# Altium schematic sheet Wacky_Racers_87557913.SchDoc(Wacky_Racers_87557913)
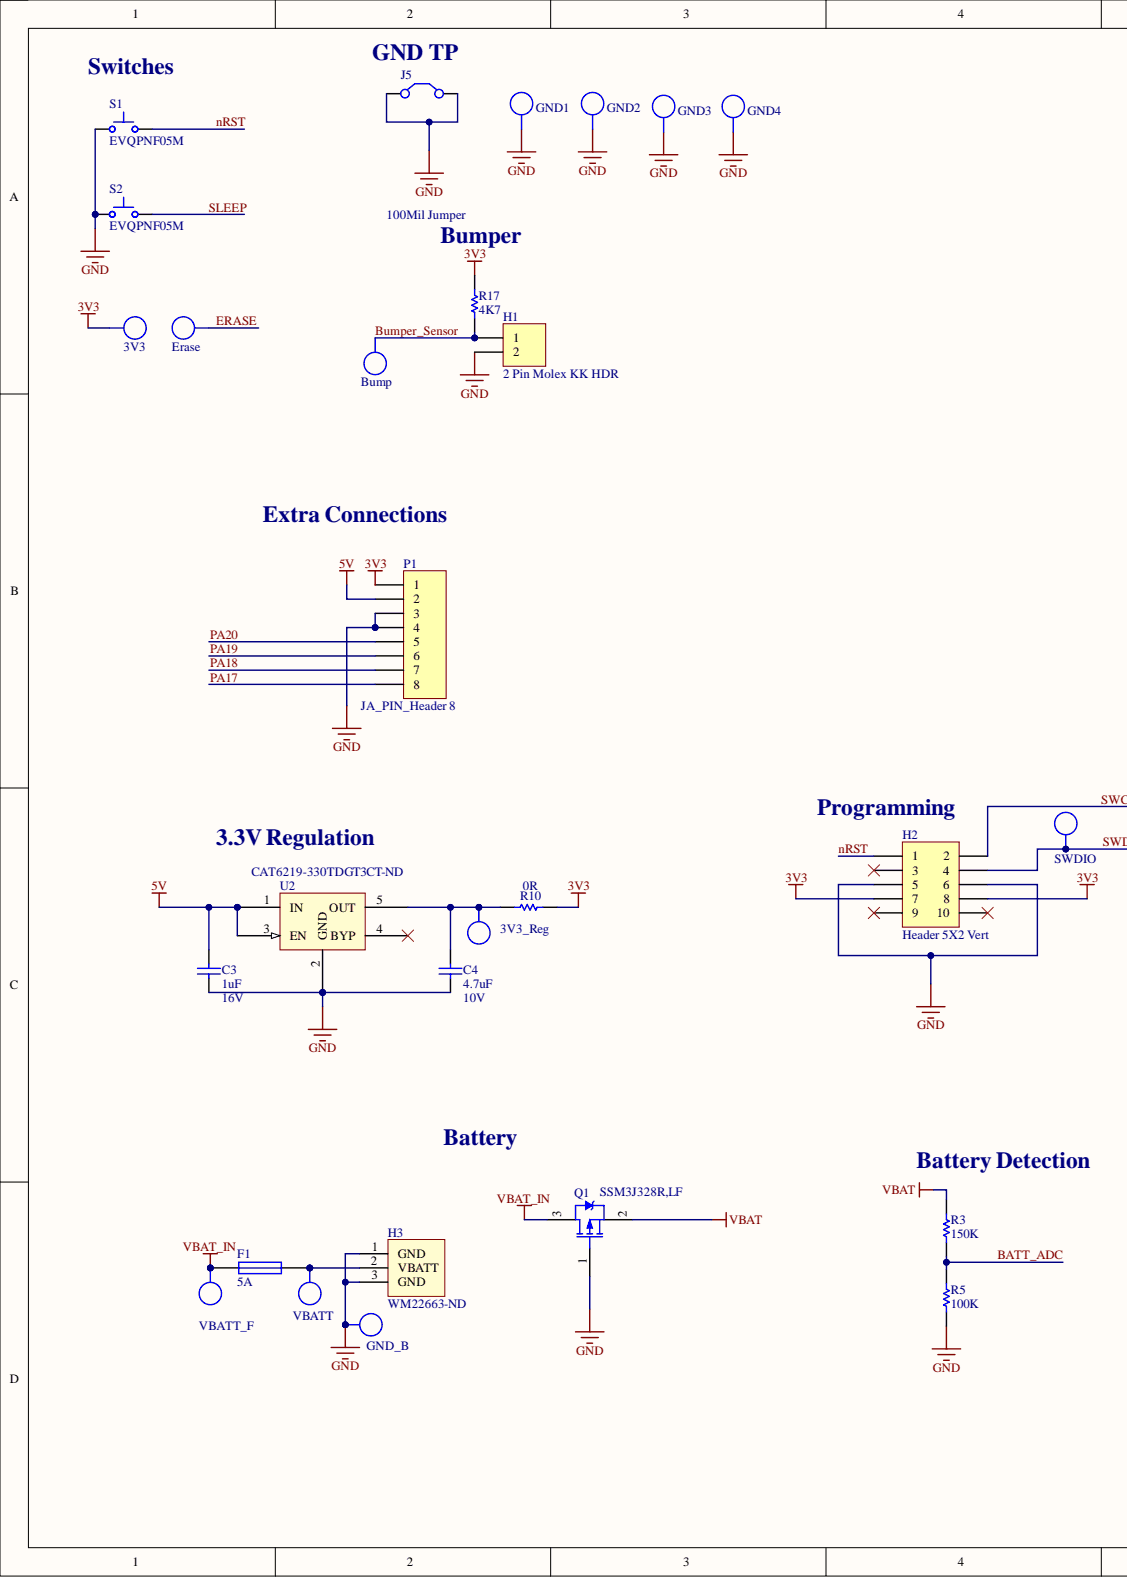
[diagram p0: Wacky_Racers_87557913.SchDoc, left]
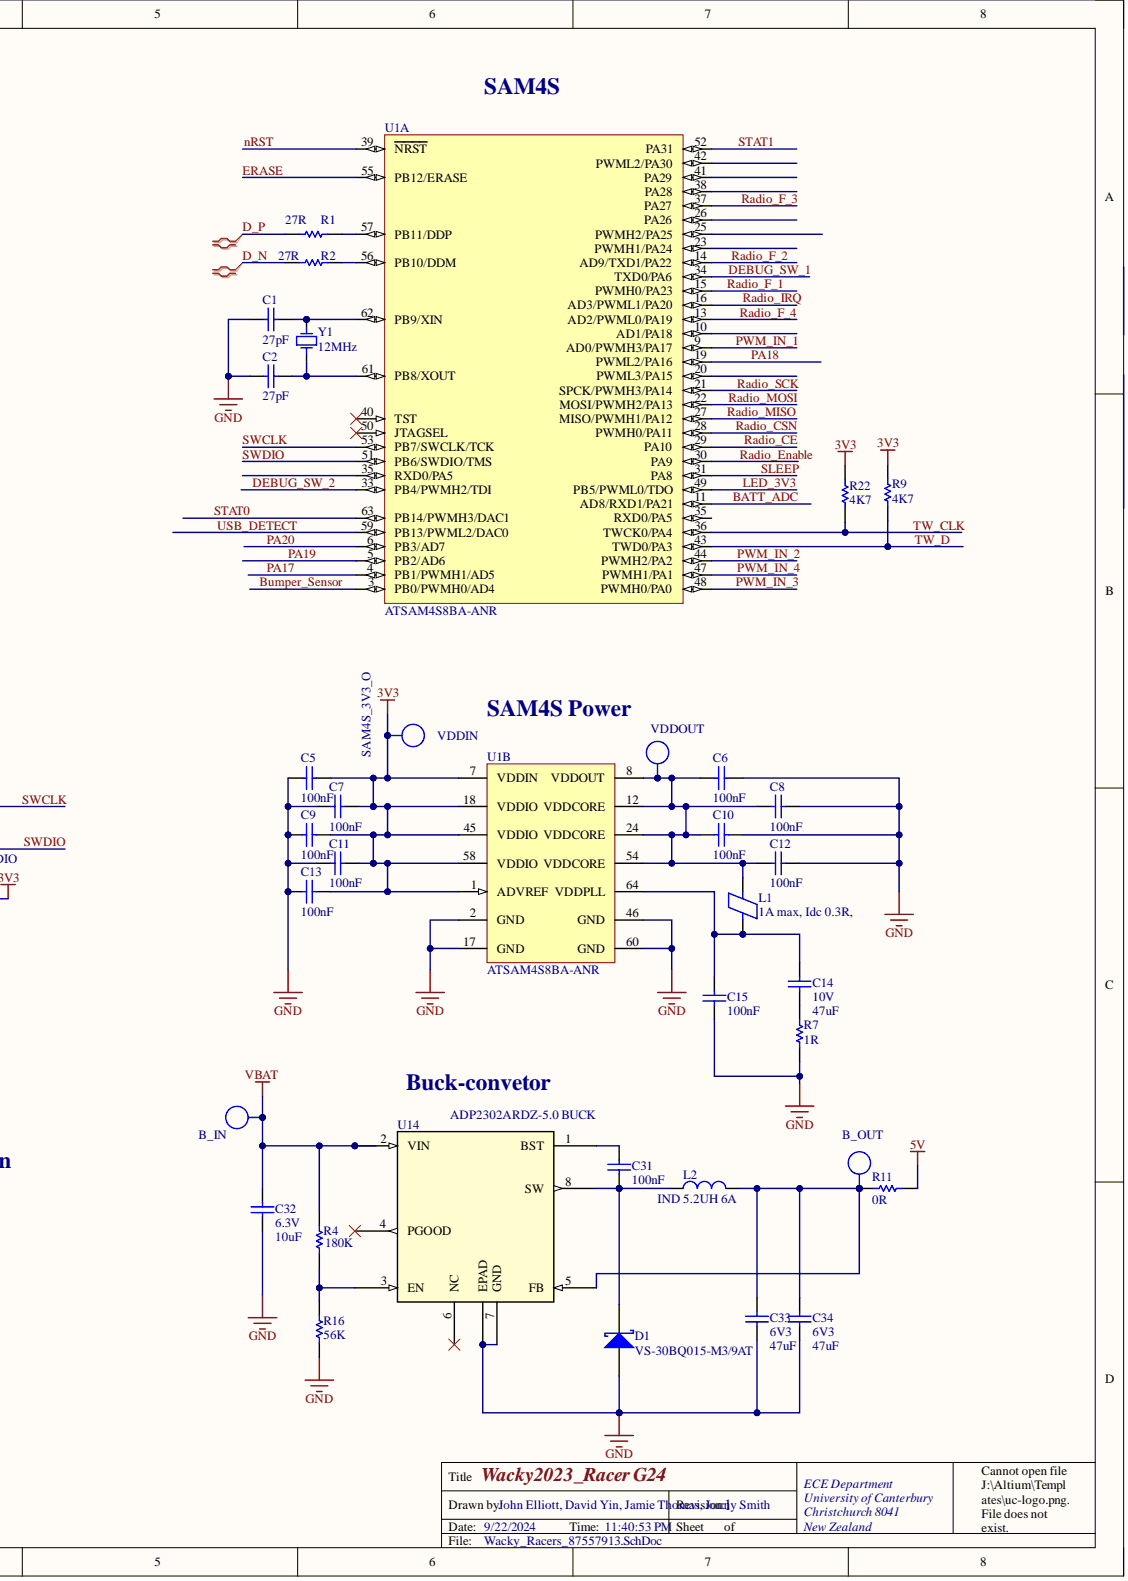
[diagram p1: Wacky_Racers_87557913.SchDoc, right]

## Parts
3V3  TP  (value not drawn)  (no BOM)
3V3_Reg  TP  (value not drawn)  (no BOM)
B_IN  TP  (value not drawn)  (no BOM)
B_OUT  TP  (value not drawn)  (no BOM)
Bump  TP  (value not drawn)  (no BOM)
C1  27pF
C2  27pF
C3  1uF  Max Voltage: 16V
C4  4.7uF  Max Voltage: 10V
C5  100nF
C6  100nF
C7  100nF
C8  100nF
C9  100nF
C10  100nF
C11  100nF
C12  100nF
C13  100nF
C14  47uF  Max Voltage: 10V  [1210 (3225 metric)]
C15  100nF
C31  100nF
C32  10uF  Voltage - Rated: 6.3V
C33  Capacitance: 47uF  Voltage Rating: 6V3  (hidden comment: CC_47uF_0805_6V3)
C34  Capacitance: 47uF  Voltage Rating: 6V3  (hidden comment: CC_47uF_0805_6V3)
D1  VS-30BQ015-M3/9AT
Erase  TP  (value not drawn)  (no BOM)
F1  5A
GND1  TP  (value not drawn)  (no BOM)
GND2  TP  (value not drawn)  (no BOM)
GND3  TP  (value not drawn)  (no BOM)
GND4  TP  (value not drawn)  (no BOM)
GND_B  TP  (value not drawn)  (no BOM)
H1  2 Pin Molex KK HDR
H2  Header 5X2 Vert
H3  Supplier Part Number 1: WM22663-ND  (hidden comment: Battery_HEADER_3pin)
J5  100Mil Jumper
L1  1A max, Idc 0.3R,
L2  IND 5.2UH 6A
P1  JA_PIN_Header 8
Q1  SSM3J328R,LF
R1  27R
R2  27R
R3  150K
R4  value 180K  (hidden comment: #NAME?)
R5  100K
R7  1R
R9  4K7
R10  0R
R11  0R
R16  56K
R17  4K7
R22  4K7
S1  EVQPNF05M
S2  EVQPNF05M
SWDIO  TP  (value not drawn)  (no BOM)
U1  ATSAM4S8BA-ANR  (parts A,B)
U2  CAT6219-330TDGT3  Supplier Part Number: CAT6219-330TDGT3CT-ND
U14  ADP2302ARDZ-5.0 BUCK
VBATT  TP  (value not drawn)  (no BOM)
VBATT_F  TP  (value not drawn)  (no BOM)
VDDIN  TP  (value not drawn)  (no BOM)
VDDOUT  TP  (value not drawn)  (no BOM)
Y1  12MHz

## Nets
3V3: 3V3.1 C5.2 C7.2 C9.2 C11.2 C13.1 H2.7 H2.8 P1.1 R9.1 R10.2 R17.2 R22.1 U1.1(ADVREF) U1.7(VDDIN) U1.18(VDDIO) U1.45(VDDIO) U1.58(VDDIO) VDDIN.1
5V: C3.2 P1.2 R11.1 U2.1(IN) U2.3(EN)
GND: C1.1 C2.1 C3.1 C4.1 C5.1 C6.2 C7.1 C8.2 C9.1 C10.2 C11.1 C12.2 C13.2 C15.1 C32.1 C33.1 C34.1 D1.1 GND1.1 GND2.1 GND3.1 GND4.1 GND_B.1 H1.2 H2.5 H2.6 H3.1 H3.3 J5.1 J5.2 P1.3 P1.4 Q1.1 R5.1 R7.1 R16.1 S1.1 S2.1 U1.2(GND) U1.17(GND) U1.46(GND) U1.60(GND) U2.2(GND) U14.7(GND) U14.EPAD
VBAT: B_IN.1 C32.2 Q1.2 R3.2 R4.2 U14.2(VIN)
VBAT_IN: F1.1 Q1.3 VBATT_F.1
BATT_ADC: R3.1 R5.2 U1.11(AD8/RXD1/PA21)
Bumper_Sensor: Bump.1 H1.1 R17.1 U1.3(PB0/PWMH0/AD4)
D_N: R2.1
D_P: R1.1
DEBUG_SW_1: U1.34(TXD0/PA6)
DEBUG_SW_2: U1.33(PB4/PWMH2/TDI)
ERASE: Erase.1 U1.55(PB12/ERASE)
LED_3V3: U1.49(PB5/PWML0/TDO)
nRST: H2.1 S1.2 U1.39(NRST)
PA17: P1.8 U1.4(PB1/PWMH1/AD5)
PA18: P1.7 U1.19(PWML2/PA16)
PA19: P1.6 U1.5(PB2/AD6)
PA20: P1.5 U1.6(PB3/AD7)
PWM_IN_1: U1.9(AD0/PWMH3/PA17)
PWM_IN_2: U1.44(PWMH2/PA2)
PWM_IN_3: U1.48(PWMH0/PA0)
PWM_IN_4: U1.47(PWMH1/PA1)
Radio_CE: U1.29(PA10)
Radio_CSN: U1.28(PWMH0/PA11)
Radio_Enable: U1.30(PA9)
Radio_F_1: U1.15(PWMH0/PA23)
Radio_F_2: U1.14(AD9/TXD1/PA22)
Radio_F_3: U1.37(PA27)
Radio_F_4: U1.13(AD2/PWML0/PA19)
Radio_IRQ: U1.16(AD3/PWML1/PA20)
Radio_MISO: U1.27(MISO/PWMH1/PA12)
Radio_MOSI: U1.22(MOSI/PWMH2/PA13)
Radio_SCK: U1.21(SPCK/PWMH3/PA14)
SLEEP: S2.2 U1.31(PA8)
STAT0: U1.63(PB14/PWMH3/DAC1)
STAT1: U1.52(PA31)
SWCLK: H2.2 U1.53(PB7/SWCLK/TCK)
SWDIO: H2.4 SWDIO.1 U1.51(PB6/SWDIO/TMS)
TW_CLK: R22.2 U1.36(TWCK0/PA4)
TW_D: R9.2 U1.43(TWD0/PA3)
USB_DETECT: U1.59(PB13/PWML2/DAC0)
Net3V3_Reg_1: 3V3_Reg.1 C4.2 R10.1 U2.5(OUT)
NetB_OUT_1: B_OUT.1 C33.2 C34.2 L2.2 R11.2 U14.5(FB)
NetC1_2: C1.2 U1.62(PB9/XIN) Y1.3
NetC2_2: C2.2 U1.61(PB8/XOUT) Y1.1
NetC6_1: C6.1 C8.1 C10.1 C12.1 L1.2 U1.8(VDDOUT) U1.12(VDDCORE) U1.24(VDDCORE) U1.54(VDDCORE) VDDOUT.1
NetC14_1: C14.1 R7.2
NetC14_2: C14.2 C15.2 L1.1 U1.64(VDDPLL)
NetC31_1: C31.1 D1.2 L2.1 U14.8(SW)
NetC31_2: C31.2 U14.1(BST)
NetF1_2: F1.2 H3.2 VBATT.1
NetR1_2: R1.2 U1.57(PB11/DDP)
NetR2_2: R2.2 U1.56(PB10/DDM)
NetR4_1: R4.1 R16.2 U14.3(EN)

Not connected: H2 3 9 10; U1 10(AD1/PA18) 20(PWML3/PA15) 23(PWMH1/PA24) 25(PWMH2/PA25) 26(PA26) 35(RXD0/PA5) 38(PA28) 40(TST) 41(PA29) 42(PWML2/PA30) 50(JTAGSEL); U2 4(BYP); U14 4(PGOOD) 6(NC)

## Library data of the parts
3V3 3V3_Reg B_IN B_OUT Bump Erase GND1-GND4 GND_B SWDIO VBATT VBATT_F VDDIN VDDOUT = TP_1206: Test-Point 3.3x1.6x2.1mm SMD; Manufacturer: Harwin Inc; Manufacturer Part Number: S1751-46R; Library Name: ECE AD19Components_22V1.IntLib; Mounting Type: Surface Mount; Storage Location: ProtoPlace; Supplier: ECE Dept; Supplier 1: Digi-Key; Supplier 2: Farnell; Supplier Part Number 1: 952-1478-2-ND; Supplier Part Number 2: 1826282; Type: PC Test Point; Value: TP
C1 C2 = CC_27pF_0603: CAP CER 27pF NPO/COG +-5% 50V 0603; Code_IPC: 1608 [0603]; Component Kind: Standard; Library Name: ECE AD19Components_22V1.IntLib; PackageReference: 0603; Storage Location: PCB Assembly WS; Supplier: ECE Dept; Value: 27pF
C3 = CC_1uF_0603: CAP CER 1uF Y5V -20% +80% 16V 0603; Code_IPC: 1608 [0603]; Component Kind: Standard; Library Name: ECE AD19Components_22V1.IntLib; PackageReference: 0603; Storage Location: PCB Assembly WS; Supplier: ECE Dept; Value: 1uF
C4 = CC_4.7uF_0603: CAP CER 4.7uF X5R +-20%  10V 0603; Note: Footprint corresponds to dimensions for bulk cassette packaging. This case size has tightened tolerances.; Code_IPC: 2012[0805]; Component Kind: Standard; Library Name: ECE AD19Components_22V1.IntLib; PackageReference: 0805; Storage Location: PCB Assembly WS; Supplier: ECE Dept; Value: 4.7uF
C5-C13 C15 C31 = CC_100nF_0603: CAP CER 100nF X7R +-10% 50V 0603; Code_IPC: 1608 [0603]; Component Kind: Standard; Library Name: ECE AD19Components_22V1.IntLib; PackageReference: 0603; Storage Location: PCB Assembly WS; Supplier: ECE Dept; Value: 100nF
C14 = CC_47uF_1206: CAP CER 47uF X5R -/+20% 10V 1206; Component Kind: Standard; Dielectric: X5R; Library Name: ECE AD19Components_22V1.IntLib; MoV: 10V; Storage Location: PCB Assembly WS; Supplier: ECE Dept; Value: 47uF
C32 = CC_10uF_0603: CAP CER 10UF X5R +-20% 6V3 0603; Component Kind: Standard; Library Name: ECE AD19Components_22V1.IntLib; Storage Location: PCB Assembly WS; Supplier: ECE Dept; Value: 10uF
C33 C34 = CC_47uF_0805_6V3: SAMSUNG ELECTRO-MECHANICS - CL21A476MQCLRNC - CAP, 47UF, 6.3V, MLCC, 0805; Dielectric: X5R; Tolerance: 20%; Case Code (Imperial): 0805; Case Code (Metric): 2012; Case/Package: 0805; Component Kind: Standard; ComponentLink1Description: https://datasheet.ciiva.com/25723/cl21a476mqclrnc-25723991.pdf?src-supplier=Digi-Key; ComponentLink1URL: https://datasheet.ciiva.com/25723/cl21a476mqclrnc-25723991.pdf?src-supplier=Digi-Key; Construction: Flat; Contact Plating: Tin; Depth: 1.25mm; Lead Free: Lead Free; Length: 2mm; Library Name: ECE AD19Components_22V1.IntLib; Manufacturer 1: Samsung; Manufacturer Part Number 1: CL21A476MQCLRNC; Max Operating Temperature: 85°C; Min Operating Temperature: -55°C; Mount: Surface Mount; Package Quantity: 4000; Packaging: Tape and Reel; Pricing 1: 1=0.56000, 10=0.39100, 50=0.27380, 100=0.23470, 500=0.17602, 1000=0.14865 (USD); RoHS: Yes; RoHS Compliant: Yes; Series: CL; Stock 1: 94580; Supplier 1: Digi-Key; Supplier Part Number 1: 1276-2420-1-ND; Termination: Wraparound; Thickness: 0.037inch; Width: 0.049inch
D1 = DS_VS-30BQ015-M3: DIODE, SCHOTTKY, 3A, 15V, DO-214AB / SMC; Manufacturer: Vishay Semiconductors; Forward Voltage: 350mV; Max Forward Surge Current (Ifsm): 650A; Peak Reverse Current: 4mA; Average Rectified Current: 3A; Component Kind: Standard; ComponentLink1Description: https://datasheet.ciiva.com/36181/vs-30bq015-m3-9at-36181258.pdf; ComponentLink1URL: https://datasheet.ciiva.com/36181/vs-30bq015-m3-9at-36181258.pdf; Library Name: ECE AD19Components_22V1.IntLib; Manufacturer Part Number: VS-30BQ015-M3/9AT; Max Reverse Voltage (DC): 15V; Supplier: Digi-Key; Supplier 1: Digi-Key; Supplier 2: Element14; Supplier Part Number: VS-30BQ015-M3/9AT-ND; Supplier Part Number 1: VS-30BQ015-M3/9ATGIDKR-ND; Supplier Part Number 2: 2628288
F1 = FS_Fuse_5.0A: Fuse 5.0A Fast Acting 1206 SMD; Manufacturer: Bel TRP; Manufacturer Part Number: C1F5; Approvals: CE,cURus; DC Cold Resistance: 19mR; Fuse Type: Board Mount (Cartridge Style Excluded); Voltage Rating: 125V; Voltage Rating (DC): 63V; Case Code (Imperial): 1206; Case Code (Metric): 3216; Case/Package: 1206; Component Kind: Standard; ComponentLink1Description: http://www.farnell.com/datasheets/2571625.pdf; ComponentLink1URL: http://www.farnell.com/datasheets/2571625.pdf; ComponentLink2Description: http://belfuse.com/pdfs/C1F.pdf; ComponentLink2URL: http://belfuse.com/pdfs/C1F.pdf; ComponentLink3Description: http://www.mouser.com/ds/2/643/C1F-594910.pdf; ComponentLink3URL: http://www.mouser.com/ds/2/643/C1F-594910.pdf; ComponentLink4Description: https://belfuse.com/resources/StewartConnector/C1F.pdf; ComponentLink4URL: https://belfuse.com/resources/StewartConnector/C1F.pdf; Contact Plating: Nickel,Tin; Current Rating: 5A; Length: 0.126inch; Library Name: ECE AD19Components_22V1.IntLib; Max Operating Temperature: 125°C; Min Operating Temperature: -55°C; Mount: Surface Mount; Number of Pins: 2; Packaging: Tape and Reel; Pricing 1: 1=0.32, 5=0.312, 10=0.298, 50=0.2662, 100=0.2479, 250=0.22032, 500=0.1836, 1000=0.17442 (USD); Stock 1: 725; Supplier 1: Digi-Key; Supplier 2: Element14; Supplier Part Number 1: 507-1886-1-ND; Supplier Part Number 2: 2848595; Value: 5A; Voltage Rating (AC): 125V; Width: 0.062inch
H1 = JA_MOLEX_KK_2POS: CONN HEADER 2POS 2.54mm VERT_Thru Hole; Component Kind: Standard; Library Name: ECE AD19Components_22V1.IntLib; Storage Location: ECE Dept Store; Supplier: ECE Dept; Supplier 1: Farnell; Supplier Part Number 1: 1462926; Value: 2 Pin Molex KK HDR
H2 = JA_HDR_IDC_5X2_VERT: IDC Header, 2,54mm, 10-Pin, Vert; Component Kind: Standard; Library Name: ECE AD19Components_22V1.IntLib; Storage Location: ECE Dept Store; Supplier: ECE Dept; Supplier 1: Farnell; Supplier Part Number 1: 1096985
H3 = Battery_HEADER_3pin: 3Pin Header 2.54mm spacing  Digikey #WM22663-ND; Component Kind: Standard; Library Name: ENCE461AD19_V23.IntLib; Supplier 1: Digi-Key
J5 = TP_JUMPER: 2.54mm Jumper Thru Hole; No. of Positions: 2; No. of Rows: 1; Pitch Spacing: 2.54; Component Kind: Standard; Library Name: ECE AD19Components_22V1.IntLib; Storage Location: ECE$ Store; Supplier: ECE Dept; Supplier 1: Farnell; Supplier Part Number 1: 1097975
L1 = IN_Ferrite-Bead: Ferrite Bead, Rdc 0.3R, Z100MHZ 600R, Imax 1A, SMD 1206; Manufacturer: FAIR-RITE; Manufacturer Part Number: 2512066017Y1; DC Current Rating: 1; DC Resistance Max: 0.08; Ferrite Case Style: 1206 ^3216 Metric]; Frequency Range: 50MHz to 1; Impedance: 600; Impedance Tolerance: ± 25%; Component Kind: Standard; Ferrite Mounting: SMD; Library Name: ECE AD19Components_22V1.IntLib; Manufacturer PN: 2512066017Y1; Storage Location: PCB Assembly WS; Supplier: ECE Dept; Supplier 1: Farnell; Supplier Part Number 1: 1612060; Value: 1A max, Idc 0.3R,
L2 = CDRH104RNP-5R2NC: FIXED IND 5.2UH 6A 22 MOHM SMD; Manufacturer: Sumida America Components Inc.; Current - Saturation: 5.6A; DC Resistance (DCR): 22 mOhm Max; Material - Core: Ferrite; Shielding: Shielded; Tolerance: ±30%; Category: Inductors, Coils, Chokes; Component Kind: Standard; ComponentLink1Description: http://products.sumida.com/products/pdf/CDRH104R.pdf; ComponentLink1URL: http://products.sumida.com/products/pdf/CDRH104R.pdf; Frequency - Self Resonant: -; Height - Seated (Max): 0.157" (4.00mm); Library Name: ENCE461AD19_V23.IntLib; Manufacturer Part Number: CDRH104RNP-5R2NC; Mounting Type: Surface Mount; Operating Temperature: -40°C ~ 100°C; Package / Case: Nonstandard; Packaging: Cut Tape (CT); Part Status: Active; Pricing 1: 1=2.9, 10=2.678, 100=1.8743, 500=1.5173 (NZD); Q @ Freq: -; Ratings: -; RoHS: RoHS Compliant; Size / Dimension: 0.402" L x 0.394" W (10.20mm x 10.00mm); Stock 1: 3849; Supplier 1: Digi-Key; Supplier Device Package: -; Supplier Part Number 1: 308-2280-1-ND; Type: -
P1 = JA_PIN_Header 8: Header, 8-Pin; Component Kind: Standard; LatestRevisionDate: 17-Jul-2002; LatestRevisionNote: Re-released for DXP Platform.; Library Name: ECE AD19Components_22V1.IntLib; Publisher: Altium Limited
Q1 = TM_SSM3J328R: MOSFET P-CH 20V 6A SOT23-3; Manufacturer: Toshiba; Drain to Source Breakdown Voltage: -20V; Drain to Source Resistance: 47.4mR; Element Configuration: Single; FET Feature: Logic Level Gate, 1.5V Drive; FET Type: MOSFET P-Channel, Metal Oxide; Gate Charge (Qg) @ Vgs: 12.8nC @ 4.5V; Gate to Source Voltage (Vgs): 8V; Input Capacitance: 840pF; Input Capacitance (Ciss) @ Vds: 840pF @ 10V; Max Power Dissipation: 1W; Number of Channels: 1; Power Dissipation: 2W; Rds On Max: 29.8mR; Turn-Off Delay Time: 107ns; Turn-On Delay Time: 32ns; Vgs(th) (Max) @ Id: 1V @ 1mA; Case/Package: SOT-23-3; Component Kind: Standard; ComponentLink1Description: https://datasheet.ciiva.com/11158/ssm3j328r-datasheet-en-20140301-11158652.pdf; ComponentLink1URL: https://datasheet.ciiva.com/11158/ssm3j328r-datasheet-en-20140301-11158652.pdf; Continuous Drain Current (ID): 6A; Current - Continuous Drain (Id) @ 25° C: 6A; Drain to Source Voltage (Vdss): 20V; Library Name: ECE AD19Components_22V1.IntLib; Manufacturer Part Number: SSM3J328R,LF; Max Operating Temperature: 150°C; Min Operating Temperature: -55°C; Mount: Surface Mount; Number of Elements: 1; Number of Pins: 3; Package / Case: SOT-23-3 Flat Leads; Package Quantity: 3000; Packaging: Tape and Reel; Power - Max: 1W; Pricing: 1=0.79, 10=0.658, 25=0.5772, 100=0.4939, 250=0.42836, 500=0.36284, 1000=0.27972 (NZD); Radiation Hardening: No; Storage Location: PCB Assembly WS; Supplier: Digi-Key; Supplier 1: Digi-Key; Supplier Device Package: SOT-23F; Supplier Part Number: SSM3J328RLFDKR-ND; Supplier Part Number 1: SSM3J328RLFDKR-ND
R1 R2 = RS_27R: RES 27 OHM 1/10W 5% 0603 SMD; Component Kind: Standard; Library Name: ECE AD19Components_22V1.IntLib; Storage Location: PCB Assembly WS; Supplier: ECE Dept; Value: 27R
R3 = RS_150K: RES 150K OHM 1/10W 5% 0603 SMD; Component Kind: Standard; Library Name: ECE AD19Components_22V1.IntLib; Storage Location: PCB Assembly WS; Supplier: ECE Dept; Value: 150K
R4 = RS_180K: RES 180K OHM 1/10W 5% 0603 SMD; Component Kind: Standard; Library Name: ECE AD19Components_22V1.IntLib; Storage Location: PCB Assembly WS; Supplier: ECE Dept
R5 = RS_100K: RES 100K OHM 1/10W 5% 0603 SMD; Component Kind: Standard; Library Name: ECE AD19Components_22V1.IntLib; Storage Location: PCB Assembly WS; Supplier: ECE Dept; Value: 100K
R7 = RT_1R: RES 1R OHM 1/4W 5% 1206 SMD; Component Kind: Standard; Library Name: ECE AD19Components_22V1.IntLib; Storage Location: PCB Assembly WS; Supplier: ECE Dept; Value: 1R
R9 R17 R22 = RS_4K7: RES 4.7K OHM 1/10W 5% 0603 SMD; Component Kind: Standard; Library Name: ECE AD19Components_22V1.IntLib; Storage Location: PCB Assembly WS; Supplier: ECE Dept; Value: 4K7
R10 R11 = RS_0R0: RES 0.0 OHM 1/10W 5% 0603 SMD; Component Kind: Standard; Library Name: ECE AD19Components_22V1.IntLib; Storage Location: PCB Assembly WS; Supplier: ECE Dept; Value: 0R
R16 = RS_56K: RES 56.0K OHM 1/10W 5% 0603 SMD; Component Kind: Standard; Library Name: ECE AD19Components_22V1.IntLib; Storage Location: PCB Assembly WS; Supplier: ECE Dept; Value: 56K
S1 S2 = WS_EVQPNF05M: Panasonic 6 x 3.5mm SMD Light Touch Switch Type SMD; Component Kind: Standard; Library Name: ECE AD19Components_22V1.IntLib; Manufacturer: Panasonic; Manufacturer PN: EVQPNF05M; PackageDescription: 6.0 x 3.5 mm; Storage Location: PCB Assembly WS; Supplier: ECE Dept; Supplier 1: Farnell; Supplier 2: Digi-Key; Supplier Part Number 1: 2079481; Supplier Part Number 2: P13598SCT-ND
U1 = SE_ATSAM4S16BA-MU: IC MCU 32BIT 512KB FLASH 64LQFP; Manufacturer: Atmel; Connectivity: I²C, IrDA, Memory Card, SPI, SSC, UART/USART, USB; Core Processor: ARM® Cortex®-M4; Core Size: 32-Bit; Data Converters: A/D 11x12b, D/A 2x12b; Number of I/O: 47; Peripherals: Brown-out Detect/Reset, DMA, POR, PWM, WDT; Program Memory Size: 512KB (512K x 8); RAM Size: 128K x 8; Speed: 120MHz; Voltage - Supply (Vcc/Vdd): 1.62 V ~ 3.6 V; Code_JEDEC: MO-220VMMD-3; Component Kind: Standard; ComponentLink1Description: Manufacturer URL; ComponentLink1URL: http://www.atmel.com/; ComponentLink2Description: SAM4S Series Datasheet; ComponentLink2URL: http://www.atmel.com/dyn/resources/prod_documents/11100.pdf; ComponentLink3Description: SAM4S Series Summary; ComponentLink3URL: http://www.atmel.com/dyn/resources/prod_documents/11100S.pdf; Library Name: ECE AD19Components_22V1.IntLib; Manufacturer Part Number: ATSAM4S8BA-ANR; Package / Case: 64-LQFP; PackageDescription: 64-Pin Quad Flat No-Lead (QFN) Sawn, 9 x 9 x 1.0 mm Body, 0.5 mm Pitch, Exposed Pad; PackageReference: 64PS; PackageVersion: revJ, Jul-2007; Packaging: Cut Tape (CT); Program Memory Type: FLASH; Supplier 1: Digi-Key; Supplier Part Number 1: ATSAM4S8BA-ANRCT-ND
U2 = SV_CAT6219-330TDGT3: IC REG LIN 3.3V 500MA TSOT23-5; Voltage - Input (Max): 5.5V; Voltage Dropout (Max): 0.5V @ 500mA; Base Part Number: CAT6219; Component Kind: Standard; ComponentLink1Description: http://www.onsemi.com/pub/Collateral/CAT6219-D.PDF; ComponentLink1URL: http://www.onsemi.com/pub/Collateral/CAT6219-D.PDF; ComponentLink2Description: CAT6219 Datasheet; ComponentLink2URL: \\maxwell\elecapp\AltiumDesigner\Libraries\DatasheetsNew\ENCE461\CAT6219-D.PDF; Current - Output: 500mA; Library Name: ENCE461AD19_V23.IntLib; Manufacturer Part Number: CAT6219-330TDGT3; Package / Case: SOT-23-5 Thin, TSOT-23-5; Supplier: Digi-Key; Supplier 1: Digi-Key; Supplier Device Package: TSOT-23-5; Voltage - Output (Min/Fixed): 3.3V
U14 = ADP2302ARDZ-5.0-R7: IC REG BUCK 5V 2A 8SOIC; Frequency - Switching: 700kHz; Output Type: Fixed; Vendor: Analog Devices Inc; Voltage - Input (Max): 20V; Component Kind: Standard; ComponentLink1Description: ADP2302ARDZ-5.0 Datasheet; ComponentLink1URL: \\maxwell\elecapp\AltiumDesigner\Libraries\DatasheetsNew\ENCE461\ADP2302_2303.pdf; Current - Output: 2A; Library Name: ENCE461AD19_V23.IntLib; Manufacturer_Part_Number: ADP2302ARDZ-5.0-R7; Supplier 1: Digi-Key; Supplier Part Number 1: ADP2302ARDZ-5.0-R7CT-ND; Type: DEV; Value: Value; Voltage - Output (Min/Fixed): 5V
Y1 = XT_CRYSTAL_12MHz_18pF: CRYSTAL 12MHZ 18PF 6X3.5mm SMD; Manufacturer: CTS-Frequency Controls; Manufacturer Part Number: 406C35D12M00000; ESR (Equivalent Series Resistance): 60 Ohm; Frequency Stability: ±50ppm; Frequency Tolerance: ±30ppm; Operating Mode: Fundamental; Component Kind: Standard; Frequency: 12MHz; Height: 0.047" (1.20mm); Library Name: ECE AD19Components_22V1.IntLib; Load Capacitance: 18pF; Mounting Type: Surface Mount; Operating Temperature: -20°C ~ 70°C; Package / Case: 4-SMD, No Lead (DFN, LCC); Size / Dimension: 0.236" L x 0.138" W (6.00mm x 3.50mm); Supplier 1: Digi-Key; Supplier Part Number 1: CTX855CT-ND
